# Altium schematic sheet JacdacBrainRP2040 59.SchDoc
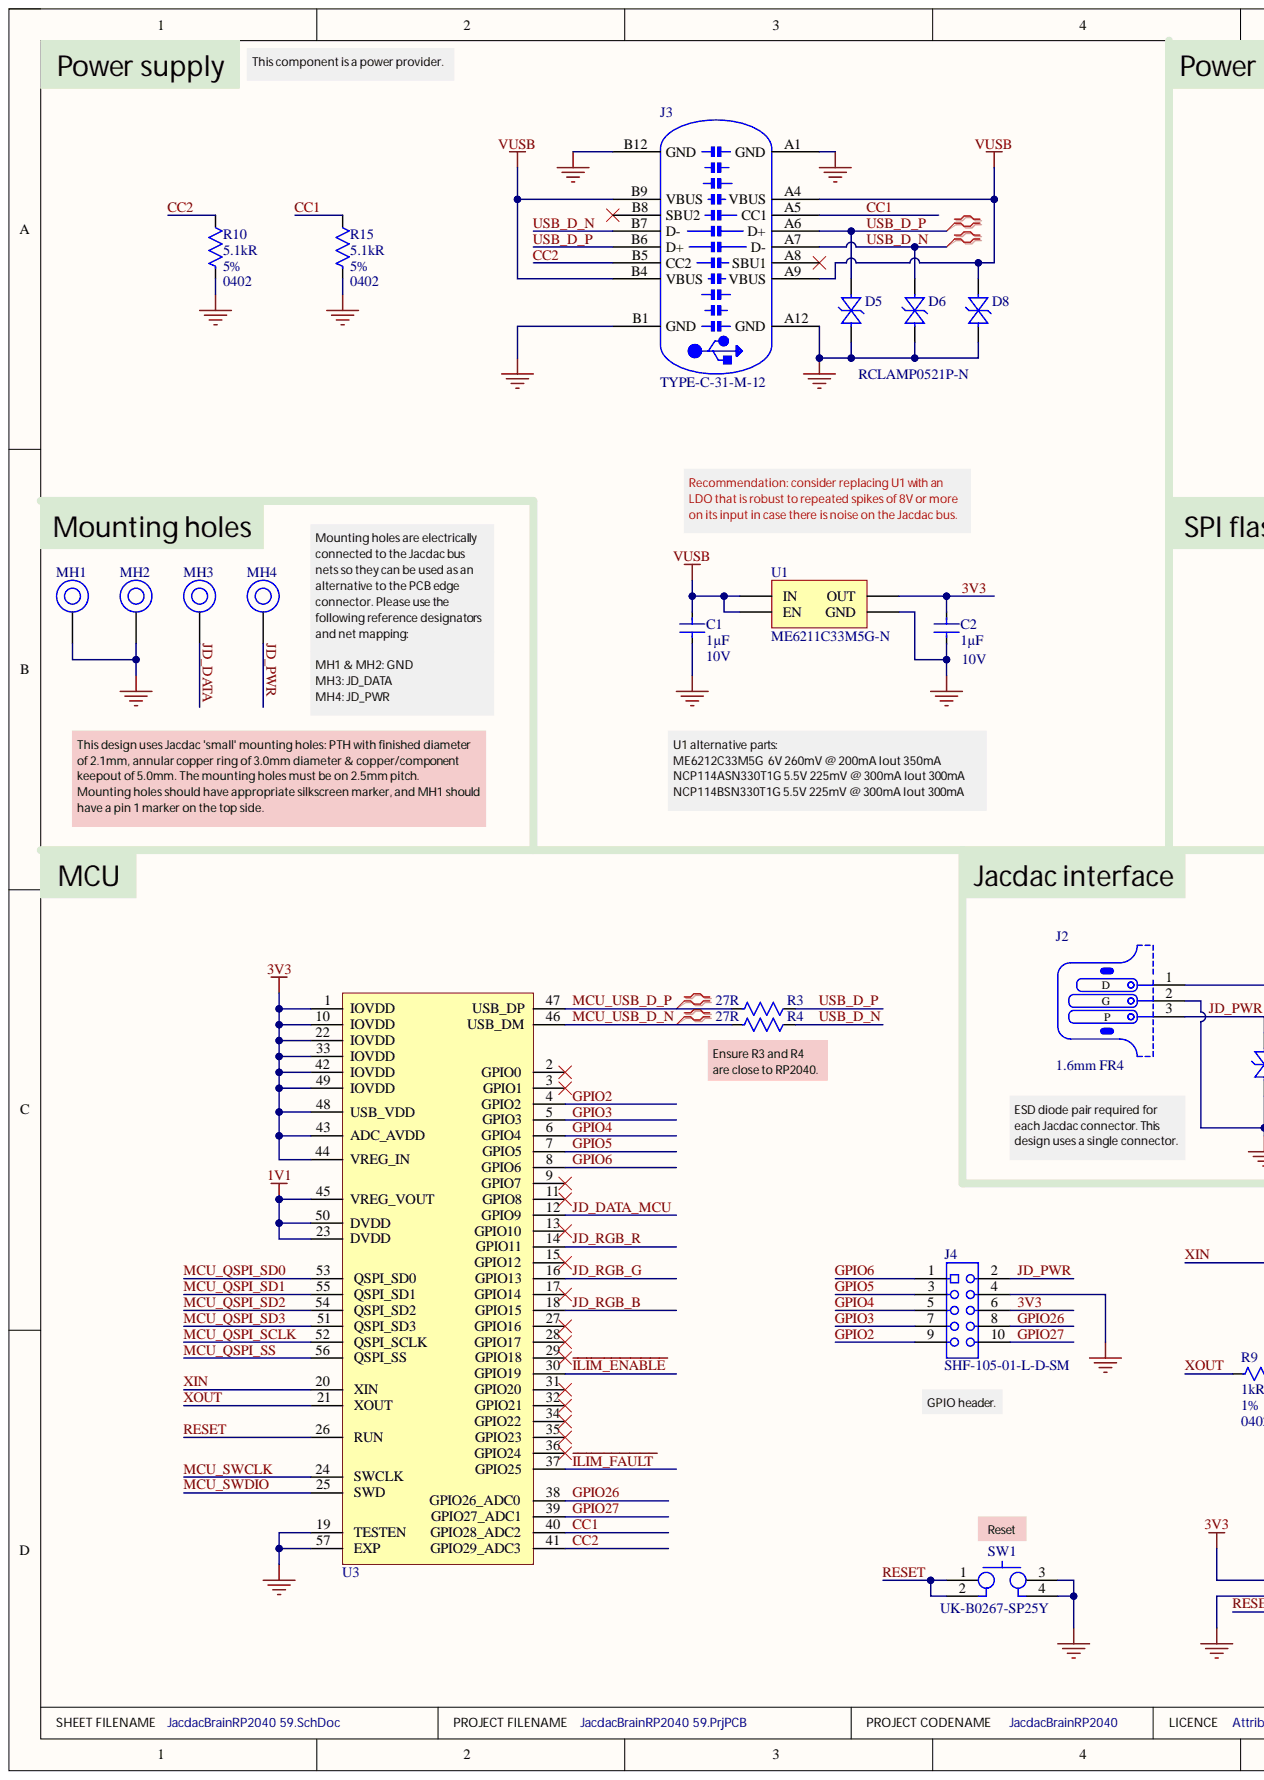
[diagram p0: JacdacBrainRP2040 59.SchDoc, left]
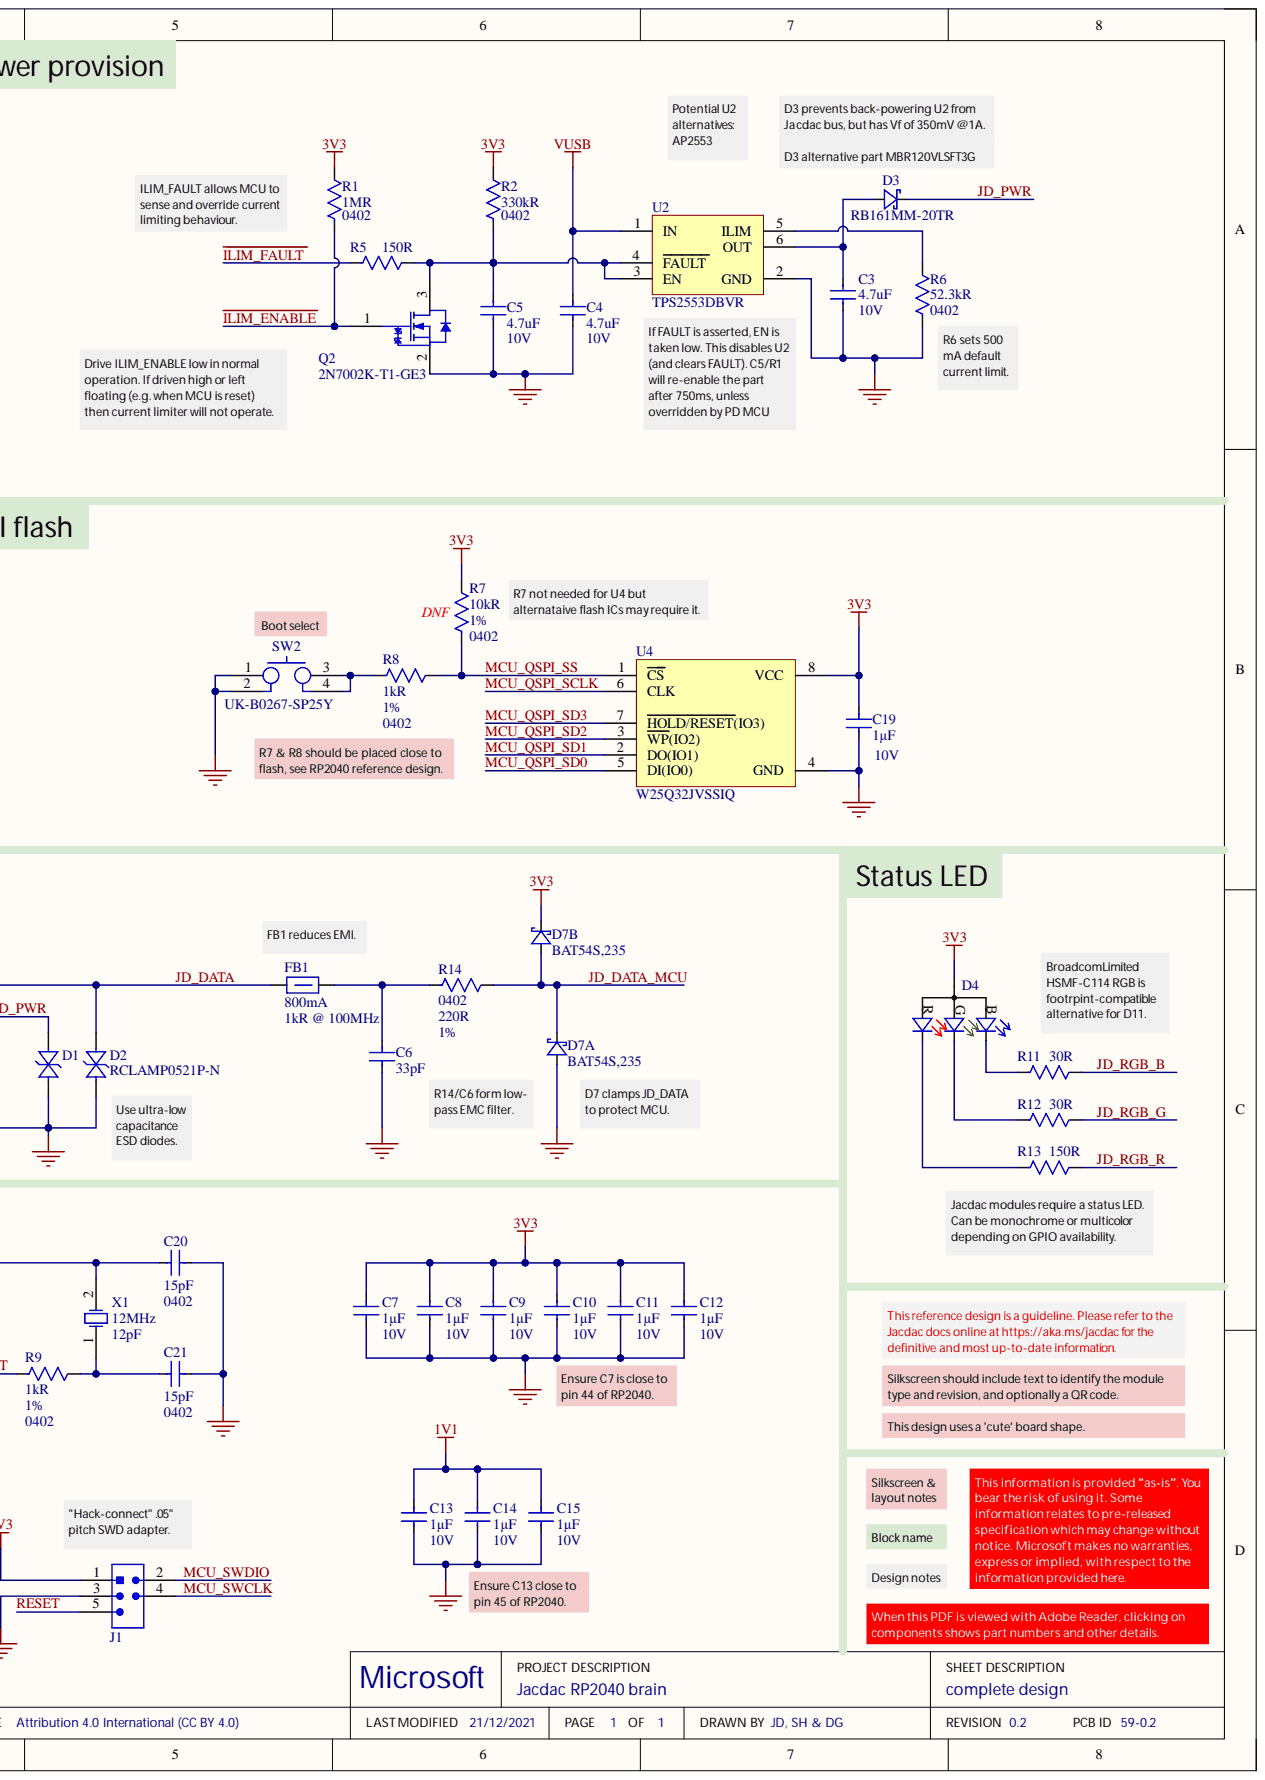
[diagram p1: JacdacBrainRP2040 59.SchDoc, right]

## Parts
C1  Capacitance: 1µF  Voltage Rating: 10V
C2  Capacitance: 1µF  Voltage Rating: 10V
C3  Capacitance: 4.7uF  Voltage Rating: 10V
C4  Capacitance: 4.7uF  Voltage Rating: 10V
C5  Capacitance: 4.7uF  Voltage Rating: 10V
C6  Capacitance: 33pF
C7  Capacitance: 1µF  Voltage Rating: 10V
C8  Capacitance: 1µF  Voltage Rating: 10V
C9  Capacitance: 1µF  Voltage Rating: 10V
C10  Capacitance: 1µF  Voltage Rating: 10V
C11  Capacitance: 1µF  Voltage Rating: 10V
C12  Capacitance: 1µF  Voltage Rating: 10V
C13  Capacitance: 1µF  Voltage Rating: 10V
C14  Capacitance: 1µF  Voltage Rating: 10V
C15  Capacitance: 1µF  Voltage Rating: 10V
C19  Capacitance: 1µF  Voltage Rating: 10V
C20  Capacitance: 15pF  Case Code (Imperial): 0402
C21  Capacitance: 15pF  Case Code (Imperial): 0402
D1  (no value)
D2  Manufacturer Part Number: RCLAMP0521P-N
D3  Manufacturer Part Number: RB161MM-20TR
D4  (no value)
D5  Manufacturer Part Number: RCLAMP0521P-N
D6  Manufacturer Part Number: RCLAMP0521P-N
D7  Manufacturer Part Number: BAT54S,235  (parts A,B)
D8  Manufacturer Part Number: RCLAMP0521P-N
FB1  Current Rating: 800mA
J1  (no value)
J2  PCB Type: 1.6mm FR4
J3  Manufacturer Part Number: TYPE-C-31-M-12
J4  Manufacturer Part Number: SHF-105-01-L-D-SM
MH1  (no value)
MH2  (no value)
MH3  (no value)
MH4  (no value)
Q2  Manufacturer Part Number: 2N7002K-T1-GE3
R1  Case Code (Imperial): 0402  Resistance: 1MR
R2  Case Code (Imperial): 0402  Resistance: 330kR
R3  Resistance: 27R
R4  Resistance: 27R
R5  Resistance: 150R
R6  Case Code (Imperial): 0402  Resistance: 52.3kR
R7  Case Code (Imperial): 0402  Resistance: 10kR  Tolerance: 1%
R8  Case Code (Imperial): 0402  Resistance: 1kR  Tolerance: 1%
R9  Case Code (Imperial): 0402  Resistance: 1kR  Tolerance: 1%
R10  Case Code (Imperial): 0402  Resistance: 5.1kR  Resistance Tolerance: 5%
R11  Resistance: 30R
R12  Resistance: 30R
R13  Resistance: 150R
R14  Case Code (Imperial): 0402  Resistance: 220R  Tolerance: 1%
R15  Case Code (Imperial): 0402  Resistance: 5.1kR  Resistance Tolerance: 5%
SW1  Manufacturer Part Number: UK-B0267-SP25Y
SW2  Manufacturer Part Number: UK-B0267-SP25Y
U1  Manufacturer Part Number: ME6211C33M5G-N
U2  Manufacturer Part Number: TPS2553DBVR
U3  (no value)
U4  Manufacturer Part Number: W25Q32JVSSIQ
X1  Frequency: 12MHz  Load Capacitance: 12pF

## Nets
1V1: C13.2 C14.2 C15.2 U3.23(DVDD) U3.45(VREG_VOUT) U3.50(DVDD)
GND: C1.1 C2.1 C3.1 C4.1 C5.1 C6.1 C7.1 C8.1 C9.1 C10.1 C11.1 C12.1 C13.1 C14.1 C15.1 C19.1 C20.2 C21.2 D1.2 D2.2 D5.2 D6.2 D7.1 D8.2 J1.3 J2.2 J3.A1(GND) J3.A12(GND) J3.B1(GND) J3.B12(GND) J4.4 MH1.1 MH2.1 Q2.2 R6.1 R10.2 R15.2 SW1.3 SW1.4 SW2.1 SW2.2 U1.2(GND) U2.2(GND) U3.19(TESTEN) U3.57(EXP) U4.4(GND)
VUSB: C1.2 C4.2 D8.1 J3.A4(VBUS) J3.A9(VBUS) J3.B4(VBUS) J3.B9(VBUS) U1.1(IN) U1.3(EN) U2.1(IN)
3V3: C2.2 C7.2 C8.2 C9.2 C10.2 C11.2 C12.2 C19.2 D4.1 D7.2 J1.1 J4.6 R1.1 R2.1 R7.1 U1.5(OUT) U3.1(IOVDD) U3.10(IOVDD) U3.22(IOVDD) U3.33(IOVDD) U3.42(IOVDD) U3.43(ADC_AVDD) U3.44(VREG_IN) U3.48(USB_VDD) U3.49(IOVDD) U4.8(VCC)
~{ILIM_ENABLE}: Q2.1 R1.2 U3.30(GPIO19)
~{ILIM_FAULT}: R5.1 U3.37(GPIO25)
CC1: J3.A5(CC1) R15.1 U3.40(GPIO28_ADC2)
CC2: J3.B5(CC2) R10.1 U3.41(GPIO29_ADC3)
GPIO2: J4.9 U3.4(GPIO2)
GPIO3: J4.7 U3.5(GPIO3)
GPIO4: J4.5 U3.6(GPIO4)
GPIO5: J4.3 U3.7(GPIO5)
GPIO6: J4.1 U3.8(GPIO6)
GPIO26: J4.8 U3.38(GPIO26_ADC0)
GPIO27: J4.10 U3.39(GPIO27_ADC1)
JD_DATA: D2.1 FB1.1 J2.1 MH3.1
JD_DATA_MCU: D7.3 R14.1 U3.12(GPIO9)
JD_PWR: D1.1 D3.1 J2.3 J4.2 MH4.1
JD_RGB_B: R11.2 U3.18(GPIO15)
JD_RGB_G: R12.2 U3.16(GPIO13)
JD_RGB_R: R13.2 U3.14(GPIO11)
MCU_QSPI_SCLK: U3.52(QSPI_SCLK) U4.6(CLK)
MCU_QSPI_SD0: U3.53(QSPI_SD0) U4.5(DI(IO0))
MCU_QSPI_SD1: U3.55(QSPI_SD1) U4.2(DO(IO1))
MCU_QSPI_SD2: U3.54(QSPI_SD2) U4.3(WP(IO2))
MCU_QSPI_SD3: U3.51(QSPI_SD3) U4.7(HOLD/RESET(IO3))
MCU_QSPI_SS: R7.2 R8.1 U3.56(QSPI_SS) U4.1(CS)
MCU_SWCLK: J1.4 U3.24(SWCLK)
MCU_SWDIO: J1.2 U3.25(SWD)
MCU_USB_D_N: R4.1 U3.46(USB_DM)
MCU_USB_D_P: R3.1 U3.47(USB_DP)
RESET: J1.5 SW1.1 SW1.2 U3.26(RUN)
USB_D_N: D6.1 J3.A7(D-) J3.B7(D-) R4.2
USB_D_P: D5.1 J3.A6(D+) J3.B6(D+) R3.2
XIN: C20.1 U3.20(XIN) X1.2
XOUT: R9.2 U3.21(XOUT)
NetC3_2: C3.2 D3.2 U2.6(OUT)
NetC5_2: C5.2 Q2.3 R2.2 R5.2 U2.3(EN) U2.4(FAULT)
NetC6_2: C6.2 FB1.2 R14.2
NetC21_1: C21.1 R9.1 X1.1
NetD4_2: D4.2(R) R13.1
NetD4_3: D4.3(G) R12.1
NetD4_4: D4.4(B) R11.1
NetR6_2: R6.2 U2.5(ILIM)
NetR8_2: R8.2 SW2.3 SW2.4

Not connected: J3 A8(SBU1) B8(SBU2); U3 2(GPIO0) 3(GPIO1) 9(GPIO7) 11(GPIO8) 13(GPIO10) 15(GPIO12) 17(GPIO14) 27(GPIO16) 28(GPIO17) 29(GPIO18) 31(GPIO20) 32(GPIO21) 34(GPIO22) 35(GPIO23) 36(GPIO24)

## Library data of the parts
C1 C2 C7-C15 C19 = (no symbol name): CAP CER 1UF 10V X5R 0402; Manufacturer: Samsung; Manufacturer Part Number: CL05A105KP5NNNC; Material: Ceramic; Tolerance: 10%; Case Code (Imperial): 0402; Case Code (Metric): 1005; Case/Package: 0402; Category: Capacitors; ComponentLink1Description: Online datasheet; ComponentLink1URL: https://datasheet.ciiva.com/15195/getdatasheetpartid-358390-15195630.pdf; Contact Plating: Tin; Dielectric: X5R; Max Operating Temperature: 85°C; Min Operating Temperature: -55°C; Supplier 1: Digi-Key; Supplier 2: Mouser; Supplier 3: Farnell; Supplier Part Number 1: 1276-1076-1-ND; Supplier Part Number 2: 187-CL05A105KP5NNNC; Supplier Part Number 3: 3013329
C3-C5 = (no symbol name): CAP CER 4.7UF 10V X5R 0402; Manufacturer: Samsung; Manufacturer Part Number: CL05A475MP5NRNC; Tolerance: 20%; Case Code (Imperial): 0402; Case Code (Metric): 1005; Case/Package: 0402; Category: Ceramic Capacitors; ComponentLink1Description: Online datasheet; ComponentLink1URL: https://datasheet.ciiva.com/25786/cl05a475mp5nrnc-25786963.pdf; Dielectric: X5R; Max Operating Temperature: 85°C; Min Operating Temperature: -55°C; RoHS Compliant: Yes; Series: CL; Supplier 1: Digi-Key; Supplier 2: Future Electronics; Supplier Part Number 1: 1276-1482-2-ND; Supplier Part Number 2: 2103694
C6 = (no symbol name): CAP CER 33PF 50V NP0 0402; Manufacturer: Samsung; Manufacturer Part Number: CL05C330JB51PNC; Tolerance: 5%; Case Code (Imperial): 0402; Case Code (Metric): 1005; Case/Package: 0402; Category: Capacitors; ComponentLink1Description: Samsung datasheet online; ComponentLink1URL: http://www.samsungsem.com/kr/support/library/product-catalog/mlcc.jsp; Max Operating Temperature: 125°C; Min Operating Temperature: -55°C; Ratings: AEC-Q200; Supplier 1: Digi-Key; Supplier 2: Mouser; Supplier 3: Future Electronics; Supplier Part Number 1: 1276-6515-1-ND; Supplier Part Number 2: 187-CL05C330JB51PNC; Supplier Part Number 3: 6022520; Voltage Rating: 50V
C20 C21 = (no symbol name): CAP CER 15PF 50V C0G/NP0 0402; Manufacturer: Samsung; Manufacturer Part Number: CL05C150JB5NNNC; Dielectric: C0G; Material: Ceramic; Temperature Coefficient: 300ppm/°C; Tolerance: 5%; Case Code (Metric): 1005; Case/Package: 0402; Category: Ceramic Capacitors; ComponentLink1Description: Online datasheet; ComponentLink1URL: https://datasheet.ciiva.com/15195/getdatasheetpartid-497170-15195669.pdf; Construction: Flat; Contact Plating: Tin; Max Operating Temperature: 125°C; Min Operating Temperature: -55°C; RoHS Compliant: Yes; Series: CL; Supplier 1: Digi-Key; Supplier 2: Mouser; Supplier Part Number 1: 1276-1179-1-ND; Supplier Part Number 2: 187-CL05C150JB5NNNC; Voltage: 50V; Voltage Rating: 50V
D1 = (no symbol name): Bidirectional 5V (Max) 6V 16V 3.5A (8/20us) 0402 TVS RoHS; Manufacturer: Born Semiconductor; Manufacturer Part Number: RCLAMP0521P-N; ComponentLink1Description: Online datasheet; ComponentLink1URL: https://datasheet.lcsc.com/szlcsc/Bourne-Semicon-Shenzhen-RCLAMP0521P-N_C316043.pdf; Package: 0402; Supplier1: LCSC; Supplier1 Part Number: C316043
D2 D5 D6 D8 = (no symbol name): Bidirectional 5V (Max) 6V 16V 3.5A (8/20us) 0402 TVS RoHS; Manufacturer: Born Semiconductor; ComponentLink1Description: Online datasheet; ComponentLink1URL: https://datasheet.lcsc.com/szlcsc/Bourne-Semicon-Shenzhen-RCLAMP0521P-N_C316043.pdf; Package: 0402; Supplier1: LCSC; Supplier1 Part Number: C316043
D3 = (no symbol name): ROHM - RB161MM-20TR - Schottky-Gleichrichterdiode, 20 V, 1 A, Einfach, SOD-123FL, 2 Pin(s), 350 mV; Average Rectified Current: 1A; Max Reverse Voltage (DC): 25V; Case/Package: SOD; Category: Rectifier Diodes; ComponentLink1Description: Online datasheet; ComponentLink1URL: http://www.mouser.com/ds/2/348/rb161mm-20tr-e-1138856.pdf; Manufacturer: Rohm; Max Operating Temperature: 125°C; Number of Pins: 2; RoHS Compliant: Yes; Supplier 1: Digi-Key; Supplier 2: RSComponents; Supplier 3: Mouser; Supplier Part Number 1: RB161MM-20TR-ND; Supplier Part Number 2: 1246775; Supplier Part Number 3: 755-RB161MM-20TR
D4 = (no symbol name): 1615 Light Emitting Diodes (LED) RoHS; Manufacturer: TUOZHAN; Manufacturer Part Number: TZ-P4-1615RGBTCA1-0.55T; Category: Optoelectronics; ComponentLink1Description: Online datasheet; ComponentLink1URL: https://datasheet.lcsc.com/szlcsc/2009041238_TUOZHAN-TZ-P4-1615RGBTCA1-0-55T_C779813.pdf; Package: 1615; Size / Dimension: 1.6x1.5mm; Supplier 1: LCSC; Supplier 1 Part Number: C779813
D7 = (no symbol name): DIODE ARRAY SCHOTTKY 30V SOT23; Manufacturer: Nexperia; Category: Rectifier Diodes; ComponentLink1Description: NXP datasheet online; ComponentLink1URL: https://assets.nexperia.com/documents/data-sheet/BAT54_SER.pdf; Supplier 1: Digi-Key; Supplier 2: Mouser; Supplier 3: RSComponents; Supplier Part Number 1: 1727-1868-1-ND; Supplier Part Number 2: 771-BAT54S235; Supplier Part Number 3: 1707990
FB1 = (no symbol name): 0603 1k@100MHz 0.8A chip; Manufacturer: TDK; Manufacturer Part Number: MPZ1608S102ATA00; Core Material: Ferrite; DC Resistance (DCR): 300mR; Impedance: 1kR @ 100MHz; Tolerance: 25%; Case Code (Imperial): 0603; Case Code (Metric): 1608; Case/Package: 0603; ComponentLink2Description: datasheet online; ComponentLink2URL: https://www.mouser.co.uk/datasheet/2/400/eads_commercial_power_mpz1608_en-923650.pdf; ComponentLink3Description: datasheet; Max DC Current: 800mA; Supplier 1: Digi-Key; Supplier 2: Mouser; Supplier Part Number 1: 445-8694-1-ND; Supplier Part Number 2: 810-MPZ1608S102ATA00; ComponentLink3URL: Y:\System\Altium\Libraries 2014\TDK\Datasheets\eads_commercial_power_mpz1608_en-923650.pdf
J1 = (no symbol name): Hack Connect Mini 1.27 mm pitch 2-row
J2 = (no symbol name): 3 pin Jacdac edge connector 1.27mm pitch; Manufacturer Part Number: JACDAC_EDGE_CONNECTOR; ComponentLink1Description: Yuliang JACDAC cable connector drawing online; ComponentLink1URL: https://github.com/microsoft/jacdac-mdk/blob/main/connector/JACDAC-Connector-by-Yuliang-2020-11-28.pdf; ComponentLink2Description: JACDAC PCB edge connector spec onlin; ComponentLink2URL: https://github.com/microsoft/jacdac-mdk/blob/main/connector/JACDAC_PCB_Edge_Connector_Drawing_JD-PEC-01_rev_01.pdf
J3 = (no symbol name): USB - Type C Female 16 USB Connectors RoHS; Manufacturer: Korean Hroparts Elec; Gender: Receptacle; Number of Positions: 16; Category: USB Connectors; ComponentLink1Description: Online datasheet; ComponentLink1URL: https://datasheet.lcsc.com/szlcsc/1811131825_Korean-Hroparts-Elec-TYPE-C-31-M-12_C165948.pdf; Orientation: Right Angle; Supplier 1: LCSC; Supplier 1 Part Number: C165948
J4 = (no symbol name): Standard Card Edge Connectors .050 Mini Edge Card Connector, Vertical; Manufacturer: Samtec; Gender: Male; Number of Contacts: 10; Pitch: 1.27mm; Category: Card Edge Connectors; ComponentLink1Description: samtec datasheet online; ComponentLink1URL: http://www.farnell.com/datasheets/1927736.pdf?src-supplier=Avnet; ComponentLink2Description: samtec datasheet; ComponentLink3Description: https://datasheet.ciiva.com/10926/mecf-10926311.pdf?src-supplier=Avnet; ComponentLink3URL: https://datasheet.ciiva.com/10926/mecf-10926311.pdf?src-supplier=Avnet; ComponentLink4Description: https://datasheet.ciiva.com/16666/mecf-16666423.pdf?src-supplier=Avnet+Europe; ComponentLink4URL: https://datasheet.ciiva.com/16666/mecf-16666423.pdf?src-supplier=Avnet+Europe; Contact Material: Bronze; Contact Plating: Gold; Housing Color: Black; Housing Material: Polymer; Max Operating Temperature: 125°C; Min Operating Temperature: -55°C; Number of Rows: 2; Orientation: Straight; Pricing 6: 1=4.53000, 10=4.34400, 25=3.98200, 50=3.80100, 100=3.62000, 250=3.16752, 500=3.07700, 1000=2.62450, 2500=2.44350 (USD); Stock 6: 49; Supplier 1: Samtec; Supplier 2: Digi-Key; Supplier 3: Farnell; Supplier 4: Mouser; Supplier 5: RSComponents; Supplier 6: Digi-Key; Supplier Part Number 1: SHF-105-01-L-D-SM; Supplier Part Number 2: SAM10710-ND; Supplier Part Number 3: 1885915; Supplier Part Number 4: 200-SHF10501LDSM; Supplier Part Number 5: 9011854; Supplier Part Number 6: SAM11496-ND; Termination: Solder; ComponentLink2URL: Y:\System\Altium\Libraries 2014\Samtec
MH1-MH4 = (no symbol name): Mounting Hole 5.0mm keepout, 3.0mm annualar ring and 2.1mm Drill PTH
Q2 = (no symbol name): Trans MOSFET N-CH Si 60V 0.3A 3-Pin SOT-23 T/R; Manufacturer: Vishay; Continuous Drain Current (ID): 300mA; Drain to Source Resistance: 2R; Element Configuration: Single; Gate to Source Voltage (Vgs): 20V; Input Capacitance: 30pF; Max Power Dissipation: 350mW; Nominal Vgs: 2V; Number of Channels: 1; Threshold Voltage: 1V; Turn-Off Delay Time: 35ns; Turn-On Delay Time: 25ns; Case/Package: SOT-23; Category: MOSFETs; ComponentLink1Description: Online datasheet; ComponentLink1URL: https://datasheet.ciiva.com/2957/2n7002k-2957216.pdf; Contact Plating: Tin,Matte; Drain to Source Breakdown Voltage: 60V; Drain to Source Voltage (Vdss): 60V; Max Operating Temperature: 150°C; Min Operating Temperature: -55°C; Number of Elements: 1; Number of Pins: 3; On-State Resistance: 2R; Power Dissipation: 350mW; Rds On Max: 2R; Supplier 1: Digi-Key; Supplier 2: RSComponents; Supplier Part Number 1: 2N7002K-T1-GE3CT-ND; Supplier Part Number 2: 7879058P; Voltage: 60V; Weight: 0.050717oz
R1 = (no symbol name): RES SMD 1M OHM 1% 1/16W 0402; Manufacturer: Yageo; Manufacturer Part Number: RC0402FR-071ML; Max Power Dissipation: 63mW; Temperature Coefficient: 100ppm/°C; Voltage Rating: 50V; Case Code (Metric): 1005; Case/Package: 0402; Category: Chip SMD Resistors; ComponentLink1Description: Online datasheet; ComponentLink1URL: https://datasheet.ciiva.com/11808/0900766b80c66297-11808125.pdf; Construction: Rectangular; Features: Moisture Resistant; Max Operating Temperature: 155°C; Min Operating Temperature: -55°C; Number of Terminations: 2; Power Rating: 63mW; Resistance Tolerance: 1%; Resistor Type: Thick Film; RoHS Compliant: Yes; Series: RC; Size Code: 0402; Supplier 1: Digi-Key; Supplier 2: Mouser; Supplier 3: Farnell; Supplier Part Number 1: 311-1.00MLRCT-ND; Supplier Part Number 2: 603-RC0402FR-071ML; Supplier Part Number 3: 2421892; Technology: THICK FILM; Termination: SMD/SMT; Tolerance: 1%
R2 = (no symbol name): RES SMD 330K OHM 1% 1/16W 0402; Manufacturer: Yageo; Manufacturer Part Number: RC0402FR-07330KL; Max Power Dissipation: 63mW; Temperature Coefficient: 100ppm/°C; Voltage Rating: 50V; Case Code (Metric): 1005; Case/Package: 0402; Category: Chip SMD Resistors; ComponentLink1Description: Online datasheet; ComponentLink1URL: https://datasheet.ciiva.com/2518/pyu-r-int-thick-7-2518994.pdf?src-supplier=Digi-Key; Construction: Rectangular; Features: Moisture Resistant; Max Operating Temperature: 155°C; Min Operating Temperature: -55°C; Number of Terminations: 2; Power Rating: 63mW; Resistance Tolerance: 1%; Resistor Type: Thick Film; RoHS Compliant: Yes; Series: RC; Size Code: 0402; Supplier 1: Digi-Key; Supplier 2: Mouser; Supplier 3: Farnell; Supplier Part Number 1: 311-330KLRCT-ND; Supplier Part Number 2: 603-RC0402FR-07330KL; Supplier Part Number 3: 9239537; Termination: SMD/SMT; Tolerance: 1%
R3 R4 = (no symbol name): RES SMD 27 OHM 1% 1/16W 0402; Manufacturer: Yageo; Manufacturer Part Number: RC0402FR-0727RL; Max Power Dissipation: 63mW; Power Rating: 62.5mW; Temperature Coefficient: 100ppm/°C; Voltage Rating: 50V; Case Code (Imperial): 0402; Case Code (Metric): 1005; Case/Package: 0402; Category: Chip SMD Resistors; ComponentLink1Description: Online datasheeet; ComponentLink1URL: https://datasheet.ciiva.com/11808/0900766b80c66297-11808450.pdf; Construction: Rectangular; Features: Moisture Resistant; Max Operating Temperature: 155°C; Min Operating Temperature: -55°C; Number of Terminations: 2; Resistance Tolerance: 1%; Resistor Type: Thick Film; RoHS Compliant: Yes; Series: RC; Size Code: 0402; Supplier 1: Digi-Key; Supplier 2: Farnell; Supplier 3: Future Electronics; Supplier Part Number 1: YAG3086CT-ND; Supplier Part Number 2: 9239049; Supplier Part Number 3: 2577120; Technology: THICK FILM; Termination: SMD/SMT; Tolerance: 1%; Weight: 2.3E-05oz
R5 R13 = (no symbol name): RES SMD 150 OHM 1% 1/16W 0402; Manufacturer: Yageo; Manufacturer Part Number: RC0402FR-07150RL; Max Power Dissipation: 63mW; Power Rating: 62.5mW; Temperature Coefficient: 100ppm/°C; Voltage Rating: 50V; Case Code (Imperial): 0402; Case Code (Metric): 1005; Case/Package: 0402; Category: Chip SMD Resistors; ComponentLink1Description: ine datasheet; ComponentLink1URL: https://datasheet.ciiva.com/11808/0900766b80c66297-11808422.pdf; Construction: Rectangular; Features: Moisture Resistant; Max Operating Temperature: 155°C; Min Operating Temperature: -55°C; Number of Terminations: 2; Resistance Tolerance: 1%; Resistor Type: Thick Film; RoHS Compliant: Yes; Series: RC; Supplier 1: Digi-Key; Supplier 2: Mouser; Supplier 3: Farnell; Supplier Part Number 1: 311-150LRCT-ND; Supplier Part Number 2: 603-RC0402FR-07150RL; Supplier Part Number 3: 2421893; Technology: THICK FILM; Termination: SMD/SMT; Tolerance: 1%; Working Voltage: 50V
R6 = (no symbol name): RES SMD 52.3K OHM 1% 1/16W 0402; Manufacturer: Yageo; Manufacturer Part Number: RC0402FR-0752K3L; Max Power Dissipation: 63mW; Power Rating: 62.5mW; Temperature Coefficient: 100ppm/°C; Voltage Rating: 50V; Case Code (Metric): 1005; Case/Package: 0402; Category: Chip SMD Resistors; ComponentLink1Description: Oline datasheet; ComponentLink1URL: https://datasheet.ciiva.com/16867/pyu-rc0402-51-rohs-l-6-16867930.pdf; Construction: Rectangular; Contact Plating: Tin,Matte; Features: Moisture Resistant; Max Operating Temperature: 155°C; Min Operating Temperature: -55°C; Number of Terminations: 2; Resistor Type: Thick Film; RoHS Compliant: Yes; Series: RC; Size Code: 0402; Supplier 1: Digi-Key; Supplier 2: Mouser; Supplier Part Number 1: YAG3179CT-ND; Supplier Part Number 2: 603-RC0402FR-0752K3L; Termination: SMD/SMT; Tolerance: 1%; Voltage Rating (DC): 50V; Weight: 2.3E-05oz
R7 = (no symbol name): RES SMD 10K OHM 1% 1/16W 0402; Manufacturer: Yageo; Manufacturer Part Number: RC0402FR-0710KL; Power Rating: 62.5mW; Temperature Coefficient: 100ppm/°C; Voltage Rating: 50V; Case Code (Metric): 1005; Case/Package: 0402; Category: Resistors; ComponentLink3Description: Online datasheet; ComponentLink3URL: https://datasheet.ciiva.com/7849/1686128-7849814.pdf; Lead Pitch: 0.2mm; Max Operating Temperature: 155°C; Min Operating Temperature: -55°C; Number of Pins: 2; Number of Terminations: 2; Resistor Type: Thick Film; Supplier 1: Digi-Key; Supplier 2: Mouser; Supplier 3: Farnell; Supplier Part Number 1: 311-10.0KLRCT-ND; Supplier Part Number 2: 603-RC0402FR-0710KL; Supplier Part Number 3: 2363463; Termination: SMD/SMT; Voltage Rating (DC): 50V; Working Voltage: 50V
R8 R9 = (no symbol name): RES SMD 1K OHM 1% 1/16W 0402; Manufacturer: Yageo; Manufacturer Part Number: RC0402FR-071KL; Power Rating: 62.5mW; Temperature Coefficient: 100ppm/°C; Voltage Rating: 50V; Case Code (Metric): 1005; Case/Package: 0402; Category: Resistors; ComponentLink2Description: Online datasheet; ComponentLink2URL: https://datasheet.ciiva.com/12666/pyu-rc0402-51-rohs-l-6-r-12666741.pdf; Max Operating Temperature: 155°C; Min Operating Temperature: -55°C; Number of Pins: 2; Resistor Type: Thick Film; Supplier 1: Digi-Key; Supplier 2: Mouser; Supplier 3: Farnell; Supplier Part Number 1: 311-1.00KLRDKR-ND; Supplier Part Number 2: 603-RC0402FR-071KL; Supplier Part Number 3: 2132441; Termination: SMD/SMT; Voltage Rating (DC): 50V
R10 R15 = (no symbol name): RES SMD 5.1K OHM 5% 1/16W 0402; Manufacturer: Yageo; Manufacturer Part Number: RC0402JR-075K1L; Max Power Dissipation: 63mW; Power Rating: 62.5mW; Temperature Coefficient: 100ppm/°C; Voltage Rating: 50V; Case Code (Metric): 1005; Case/Package: 0402; Category: Chip SMD Resistors; ComponentLink2Description: Onnline datasheet; ComponentLink2URL: https://datasheet.ciiva.com/16740/pyu-rc0402-51-rohs-l-6-r-16740925.pdf; Construction: Rectangular; Features: Moisture Resistant; Max Operating Temperature: 155°C; Min Operating Temperature: -55°C; Number of Terminations: 2; Resistor Type: Thick Film; RoHS Compliant: Yes; Series: RC; Size Code: 0402; Supplier 1: Digi-Key; Supplier 2: Newark; Supplier 3: Mouser; Supplier 4: Farnell; Supplier 5: Farnell; Supplier Part Number 1: 311-5.1KJRCT-ND; Supplier Part Number 2: 68R0016; Supplier Part Number 3: 603-RC0402JR-075K1L; Supplier Part Number 4: 3495714; Supplier Part Number 5: 1799293; Termination: SMD/SMT; Tolerance: 5%; Voltage Rating (DC): 50V; Weight: 2.3E-05oz
R11 R12 = (no symbol name): RES SMD 30 OHM 1% 1/16W 0402; Manufacturer: Yageo; Manufacturer Part Number: RC0402FR-0730RL; Max Power Dissipation: 63mW; Temperature Coefficient: 100ppm/°C; Voltage Rating: 50V; Case Code (Imperial): 0402; Case Code (Metric): 1005; Case/Package: 0402; Category: Chip SMD Resistors; ComponentLink1Description: Online datasheet; ComponentLink1URL: https://datasheet.ciiva.com/7849/1018594-7849958.pdf; Construction: Rectangular; Contact Plating: Tin,Matte; Features: Moisture Resistant; Max Operating Temperature: 155°C; Min Operating Temperature: -55°C; Number of Terminations: 2; Power Rating: 63mW; Resistance Tolerance: 1%; Resistor Type: Thick Film; RoHS Compliant: Yes; Series: RC; Size Code: 0402; Supplier 1: Digi-Key; Supplier 2: Mouser; Supplier 3: Farnell; Supplier Part Number 1: 311-30LRCT-ND; Supplier Part Number 2: 603-RC0402FR-0730RL; Supplier Part Number 3: 1458775; Termination: SMD/SMT; Tolerance: 1%; Voltage Rating (DC): 50V
R14 = (no symbol name): RES SMD 220 OHM 1% 1/16W 0402; Manufacturer: Yageo; Manufacturer Part Number: RC0402FR-07220RL; Max Power Dissipation: 63mW; Power Rating: 62.5mW; Temperature Coefficient: 100ppm/°C; Voltage Rating: 50V; Case Code (Metric): 1005; Case/Package: 0402; Category: Resistors; ComponentLink1Description: https://datasheet.ciiva.com/11819/0900766b80c66297-11819466.pdf?src-supplier=RSComponents; ComponentLink1URL: https://datasheet.ciiva.com/11819/0900766b80c66297-11819466.pdf?src-supplier=RSComponents; ComponentLink2Description: https://datasheet.ciiva.com/2453/pyu-r-int-thick-7-2453460.pdf?src-supplier=Digi-Key; ComponentLink2URL: https://datasheet.ciiva.com/2453/pyu-r-int-thick-7-2453460.pdf?src-supplier=Digi-Key; ComponentLink3Description: https://datasheet.ciiva.com/2453/pyu-r-int-thick-7-2453461.pdf?src-supplier=Digi-Key; ComponentLink3URL: https://datasheet.ciiva.com/2453/pyu-r-int-thick-7-2453461.pdf?src-supplier=Digi-Key; ComponentLink4Description: https://datasheet.ciiva.com/2453/pyu-r-int-thick-7-2453462.pdf?src-supplier=Digi-Key; ComponentLink4URL: https://datasheet.ciiva.com/2453/pyu-r-int-thick-7-2453462.pdf?src-supplier=Digi-Key; ComponentLink5Description: https://datasheet.ciiva.com/16746/pyu-rc0402-51-rohs-l-6-r-16746831.pdf?src-supplier=Future+Electronics; ComponentLink5URL: https://datasheet.ciiva.com/16746/pyu-rc0402-51-rohs-l-6-r-16746831.pdf?src-supplier=Future+Electronics; Features: Moisture Resistant; Max Operating Temperature: 155°C; Min Operating Temperature: -55°C; Number of Pins: 2; Number of Terminations: 2; Resistor Type: Thick Film; Supplier 1: Digi-Key; Supplier 2: Mouser; Supplier 3: Farnell; Supplier Part Number 1: 311-220LRCT-ND; Supplier Part Number 2: 603-RC0402FR-07220RL; Supplier Part Number 3: 2147864; Termination: SMD/SMT; Voltage Rating (DC): 50V
SW1 SW2 = (no symbol name): SWITCH TACTILE SSPST 50mA @ 12VDC; Manufacturer: USAKRO; Actuator: Oval; Contact Form: SPST; Operating Force: 3.9 N; Switch Function: OFF - (ON); ComponentLink1Description: Online datasheet; ComponentLink1URL: https://datasheet.ciiva.com/18514/pts810-4mar13-18514167.pdf; Current Rating: 50 mA; Mounting Style: SMD/SMT; Product Category: Tactile Switches; Supplier 1: LCSC; Supplier 1 Part Number: C493283; Voltage Rating DC: 12 VDC
U1 = (no symbol name): 6V 260mV @ 200mA(typ) - - Fixed 3.3V SOT-23-5 Linear Voltage Regulators (LDO) ROHS; manufacturer: MICRONE(Nanjing Micro One Elec); Dropout Voltage: 200mV; Max Input Voltage: 6; Number of Regulators: 1; Output Configuration: Positive; Output Current: 500mA; Case/Package: SOT-23-5; Category: Voltage Regulators - Linear; ComponentLink3Description: On Semi datasheet online; ComponentLink3URL: https://datasheet.lcsc.com/lcsc/1811131510_MICRONE-Nanjing-Micro-One-Elec-ME6211C33M5G-N_C82942.pdf; Min Output Voltage: 3.3V; Output Type: Fixed; Supplier 1: LCSC; Supplier Part Number: C82942
U2 = (no symbol name): IC PWR SWITCH N-CHAN 1:1 SOT23-6; Manufacturer: Texas Instruments; Max Input Voltage: 6.5V; Max Power Dissipation: 625mW; Min Input Voltage: 2.5V; Number of Outputs: 1; On-State Resistance: 85mR; Output Current: 1.5A; Case/Package: SOT-23; Category: Hot Swap Controllers; ComponentLink1Description: Online datasheet; ComponentLink1URL: https://datasheet.ciiva.com/15108/getdatasheetpartid-297517-15108509.pdf; Max Operating Temperature: 85°C; Max Supply Voltage: 6.5V; Min Operating Temperature: -40°C; Min Supply Voltage: 2.5V; Number of Pins: 6; Supplier 1: Digi-Key; Supplier 2: Farnell; Supplier 3: Mouser; Supplier Part Number 1: 296-32464-1-ND; Supplier Part Number 2: 3124082; Supplier Part Number 3: 595-TPS2553DBVR; Weight: 0.00127oz
U3 = (no symbol name): QFN-56_7x7 MCU; Manufacturer: Raspberry Pi; Manufacturer Part Number: RP2040; Category: Integrated Circuit; ComponentLink1Description: Online datasheet; ComponentLink1URL: https://datasheet.lcsc.com/lcsc/2108101830_Raspberry-Pi-RP2040_C2040.pdf; Supplier 1: LCSC; Supplier 1 Part Number: C2040
U4 = (no symbol name): FLASH - NOR Memory IC 32Mb (4M x 8) SPI - Quad I/O 133 MHz 8-SOIC; Manufacturer: Winbond; Frequency: 104MHz; Interface: SPI,Serial; Max Supply Voltage: 3.6V; Memory Type: FLASH,NOR; Min Supply Voltage: 2.7V; Operating Supply Voltage: 3V; Page Size: 256B; Case/Package: SOIC; Category: Flash; ComponentLink1Description: Online datasheet; ComponentLink1URL: http://www.winbond.com/resource-files/w25q32jv%20revg%2003272018%20plus.pdf; Density: 32MB; Max Operating Temperature: 85°C; Min Operating Temperature: -40°C; Number of Pins: 8; RoHS Compliant: Yes; Supplier 1: Digi-Key; Supplier Part Number 1: W25Q32JVSSIQ-ND
X1 = (no symbol name): 12MHz ±30ppm 12pF 50Ω SMD-5032_2P SMD Crystal Resonators RoHS; Manufacturer: TXC; Manufacturer Part Number: 7A12000053; ESR (Equivalent Series Resistance): 50 Ohm; Category: Crystals and Oscillators; ComponentLink1Description: Online datasheet; ComponentLink1URL: https://datasheet.lcsc.com/lcsc/1809191817_TXC-Corp-7A12000053_C90884.pdf; Family: Crystals; Frequency Tolerance: ±30ppm; Operating Temperature: -40°C ~ 85°C; Standard Package: 1; Supplier 1: LCSC; Supplier Part Number 1: C90884
